# Altium schematic sheet schematics.SchDoc
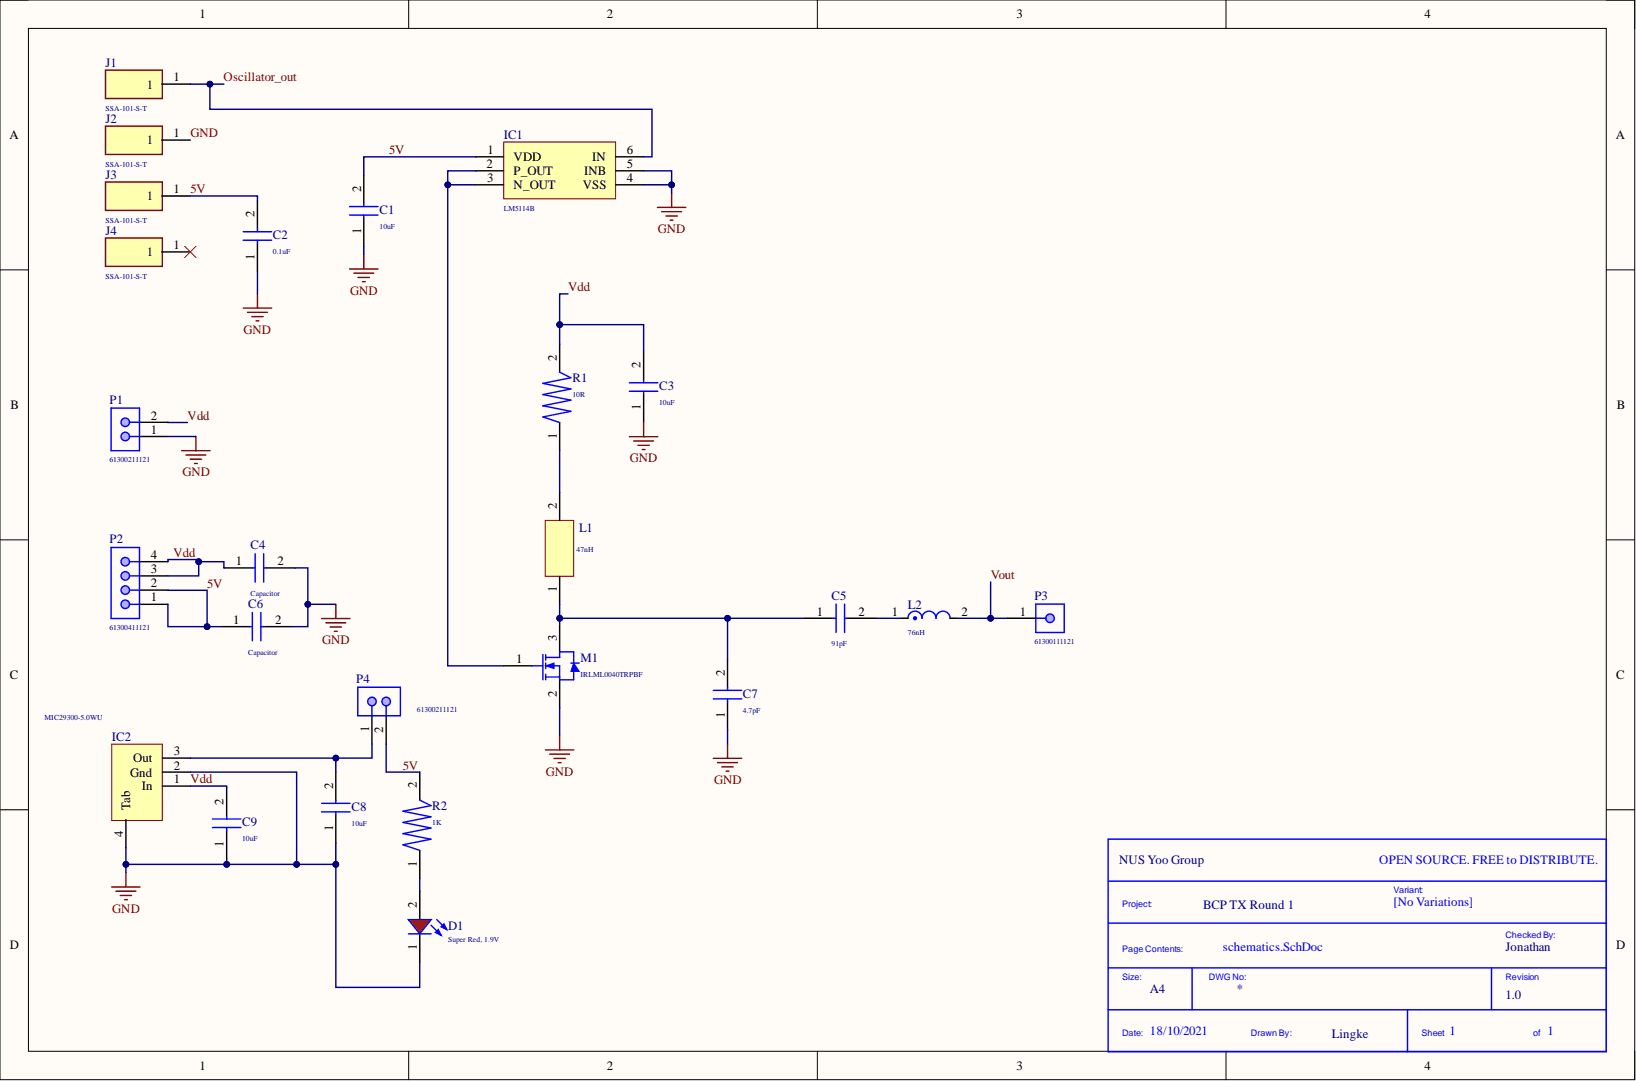
[diagram p0: schematics.SchDoc]

## Parts
*?  Checked By: Jonathan  Company: NUS Yoo Group  Drawn By: Lingke  Project Name: BCP TX Round 1  Revision: 1.0  Size: A4  (hidden comment: Title_Block)  (no BOM)
C1  10uF  [0603]
C2  0.1uF  [0603]
C3  10uF  [0603]
C4  Capacitor  [0603]
C5  91pF  [0603]
C6  Capacitor  [0603]
C7  4.7pF  [0603]
C8  10uF  [0603]
C9  10uF  [0603]
D1  Super Red, 1.9V  [WL-SMCW_0603_H=0.7mm]
IC1  LM5114B  [SOT-23-6]
IC2  MIC29300-5.0WU  [TO-263-3]
J1  SSA-101-S-T  [SSA-101-S-X]
J2  SSA-101-S-T  [SSA-101-S-X]
J3  SSA-101-S-T  [SSA-101-S-X]
J4  SSA-101-S-T  [SSA-101-S-X]
L1  47nH  [TPI078060L047N]
L2  76nH  [FP-LQP03PN_02-IPC_A]
M1  IRLML0040TRPBF  [SOT-23]
P1  61300211121  [61300211121]
P2  61300411121  [61300411121]
P3  61300111121  [61300111121]
P4  61300211121  [61300211121]
R1  10R  [2512]
R2  1K  [0603]

## Nets
5V: C1.2 C2.2 C6.1 IC1.1(VDD) J3.1 P2.1 P2.2 P4.2 R2.2
GND: C1.1 C2.1 C3.1 C4.2 C6.2 C7.1 C8.1 C9.1 D1.1 IC1.4(VSS) IC1.5(INB) IC2.2(Gnd) IC2.4(Tab) J2.1 M1.2 P1.1
Oscillator_out: IC1.6(IN) J1.1
Vdd: C3.2 C4.1 C9.2 IC2.1(In) P1.2 P2.3 P2.4 R1.2
Vout: L2.2 P3.1
NetC5_1: C5.1 C7.2 L1.1 M1.3
NetC5_2: C5.2 L2.1
NetC8_2: C8.2 IC2.3(Out) P4.1
NetD1_2: D1.2 R2.1
NetIC1_2: IC1.2(P_OUT) IC1.3(N_OUT) M1.1
NetL1_2: L1.2 R1.1

Not connected: J4 1

## Library data of the parts
*? = Title_Block: Standard Title Block.; Library Name: WPT Round 2 TX.IntLib
C1-C3 C5 C7-C9 = Capacitor: Component Kind: Standard; Description: Capacitor; Library Name: symbol_lib.SchLib
D1 = 150060SS75000: WL-SMCW SMT Mono-color Chip LED Waterclear, size 0603, Super Red, 1.9V, 140deg; Chip Technology: AlInGaP; Dominant Wavelength typ.: 630nm; Luminous Intensity typ.: 60mcd; Peak Wavelength typ.: 645nm; Component Kind: Standard; ComponentLink1Description: Manufacturer; ComponentLink1URL: http://www.we-online.de; ComponentLink2Description: Datasheet; ComponentLink2URL: https://www.we-online.com/catalog/datasheet/150060SS75000.pdf; Emitting Color: Super Red; Forward Voltage typ.: 1.9V; Library Name: Altium_WL-SMCW (rev20a).IntLib; Mounting Technology: SMT; Operating Temperature: -40 to +85degC; Packaging: Tape and Reel; Part Number: 150060SS75000; Published Date: December 2019; Size: 0603; Viewing Angle: 140deg; Publisher: Wurth Elektronik
J1-J4 = SSA-101-S-T: Connector; Manufacturer_Name: SAMTEC; Component Kind: Standard; Datasheet Link: http://suddendocs.samtec.com/prints/ssas-mkt.pdf; Library Name: symbol_lib.SchLib; Manufacturer_Part_Number: SSA-101-S-T
L1 = TPI078060L047N: Component Kind: Standard; Library Name: symbol_lib.SchLib; Supplier 1: Digi-Key; Supplier Part Number 1: 399-TPI078060L047NCT-ND
L2 = LQP03TN75NH02D: Film type RF Inductor 75nH ±3% 100mA 10Ω 0201 (0603); Core Material: Non-Magnetic; DC Resistance (DCR): 10R; Q Factor: 8; Self Resonant Frequency: 1GHz; Shielding: Unshielded; Test Frequency: 300MHz; Case Code (Imperial): 0201; Case Code (Metric): 0603; Case/Package: 0201; Component Kind: Standard; Current Rating: 100mA; Height: 0.3mm; Height - Seated (Max): 0.013inch; Inductance: 75nH; Length: 0.024inch; Library Name: symbol_lib.SchLib; Max DC Current: 100mA; Max Operating Temperature: 125°C; Min Operating Temperature: -55°C; Mount: Surface Mount; Package Quantity: 15000; Packaging: Tape and Reel; Resistor Type: Thin Film; RoHS Compliant: Yes; Supplier 1: Digi-Key; Supplier Part Number 1: 490-13018-1-ND; Termination: SMD/SMT; Tolerance: 3%; Weight: 6E-06oz; Width: 0.012inch
M1 = IRLML0040TRPBF: HEXFET(R) Power MOSFET, 40 V, -55 to 150 degC, 3-Pin SOT-23, RoHS, Tape and Reel; Manufacturer: International Rectifier; Channel Mode: Enhancement; Channel Type: N; Maximum Continuous Drain Current: 3.6A; Maximum Drain Source Resistance: 0.056Ω; Maximum Drain Source Voltage: 40V; Maximum Gate Source Voltage: ±16V; Maximum Gate Threshold Voltage: 2.5V; Maximum Power Dissipation: 1.3W; Minimum Gate Threshold Voltage: 1V; Number of Elements per Chip: 1; Transistor Configuration: Single; Transistor Material: Si; Typical Gate Charge @ Vgs: 2.6nC@4.5V; Typical Input Capacitance @ Vds: 266pF@25V; Typical Turn-Off Delay Time: 6.4ns; Typical Turn-On Delay Time: 5.1ns; Category: PowerMOSFET; Component Kind: Standard; Datasheet URL: https://datasheet.ciiva.com/22709/irlml0040pbf-22709201.pdf; Datasheet Version: 02/2012; Dimensions: 3.04x1.4x1.02mm; Height: 1.02mm; Length: 3.04mm; Library Name: symbol_lib.SchLib; Manufacturer URL: http://internationalrectifier.com/; Maximum Operating Temperature: +150°C; Minimum Operating Temperature: -55°C; Mounting Technology: SurfaceMount; Mounting Type: SurfaceMount; Package Description: 3-Pin Small Outline Transistor, Body 2.92 x 1.3 mm, Pitch 0.95 mm; Package Reference: SOT-23; Package Type: SOT-23; Pin Count: 3; Width: 1.4mm
P1 P4 = 61300211121: WR-PHD Pin Header, THT, pitch 2.54mm, Single Row, Vertical, 2p; Manufacturer: Wurth Elektronik; Contact Resistance: 20mOhm; Pin Number: 2; Rated Current: 3A; Withstanding Voltage: 500VAC; Working Voltage: 250VAC; Component Kind: Standard; ComponentLink1Description: Manufacturer; ComponentLink1URL: http://www.we-online.de; ComponentLink2Description: Datasheet; ComponentLink2URL: https://www.we-online.com/catalog/datasheet/61300211121.pdf; Gender: Pin Header; Library Name: Altium_WR-PHD (rev20a).IntLib; Manufacturer Part Number: 61300211121; Mounting Technology: THT; Operating Temperature: -40 to +105degC; Orientation: Vertical; Packaging: Bag; Pitch: 2.54mm; Published Date: May 2020; Row Number: Single
P2 = 61300411121: WR-PHD Pin Header, THT, pitch 2.54mm, Single Row, Vertical, 4p; Manufacturer: Wurth Elektronik; Contact Resistance: 20mOhm; Pin Number: 4; Rated Current: 3A; Withstanding Voltage: 500VAC; Working Voltage: 250VAC; Component Kind: Standard; ComponentLink1Description: Manufacturer; ComponentLink1URL: http://www.we-online.de; ComponentLink2Description: Datasheet; ComponentLink2URL: https://www.we-online.com/catalog/datasheet/61300411121.pdf; Gender: Pin Header; Library Name: Altium_WR-PHD (rev20a).IntLib; Manufacturer Part Number: 61300411121; Mounting Technology: THT; Operating Temperature: -40 to +105degC; Orientation: Vertical; Packaging: Bag; Pitch: 2.54mm; Published Date: May 2020; Row Number: Single
P3 = 61300111121: WR-PHD Pin Header, THT, pitch 2.54mm, Single Row, Vertical, 1p; Manufacturer: Wurth Elektronik; Contact Resistance: 20mOhm; Pin Number: 1; Rated Current: 3A; Withstanding Voltage: 500VAC; Working Voltage: 250VAC; Component Kind: Standard; ComponentLink1Description: Manufacturer; ComponentLink1URL: http://www.we-online.de; ComponentLink2Description: Datasheet; ComponentLink2URL: https://www.we-online.com/catalog/datasheet/61300111121.pdf; Gender: Pin Header; Library Name: Altium_WR-PHD (rev20a).IntLib; Manufacturer Part Number: 61300111121; Mounting Technology: THT; Operating Temperature: -40 to +105degC; Orientation: Vertical; Packaging: Bag; Pitch: 2.54mm; Published Date: May 2020; Row Number: Single
R1 = Resistor_2512: Resistor; Component Kind: Standard; Library Name: symbol_lib.SchLib
R2 = Resistor: Component Kind: Standard; Description: Resistor; Library Name: symbol_lib.SchLib
C4 C6 = Capacitor: Component Kind: Standard; Description: Capacitor; Library Name: symbol_lib.SchLib
IC1 = LM5114B: Component Kind: Standard; Library Name: symbol_lib.SchLib
IC2 = MIC29300-5.0WU: Component Kind: Standard; Library Name: symbol_lib.SchLib; Supplier 1: Digi-Key; Supplier Part Number 1: 576-1122-ND
